# Altium schematic sheet PAGE3-BLE, LORA, RTC.SchDoc("PAGE3-BLE, LORA, RTC")
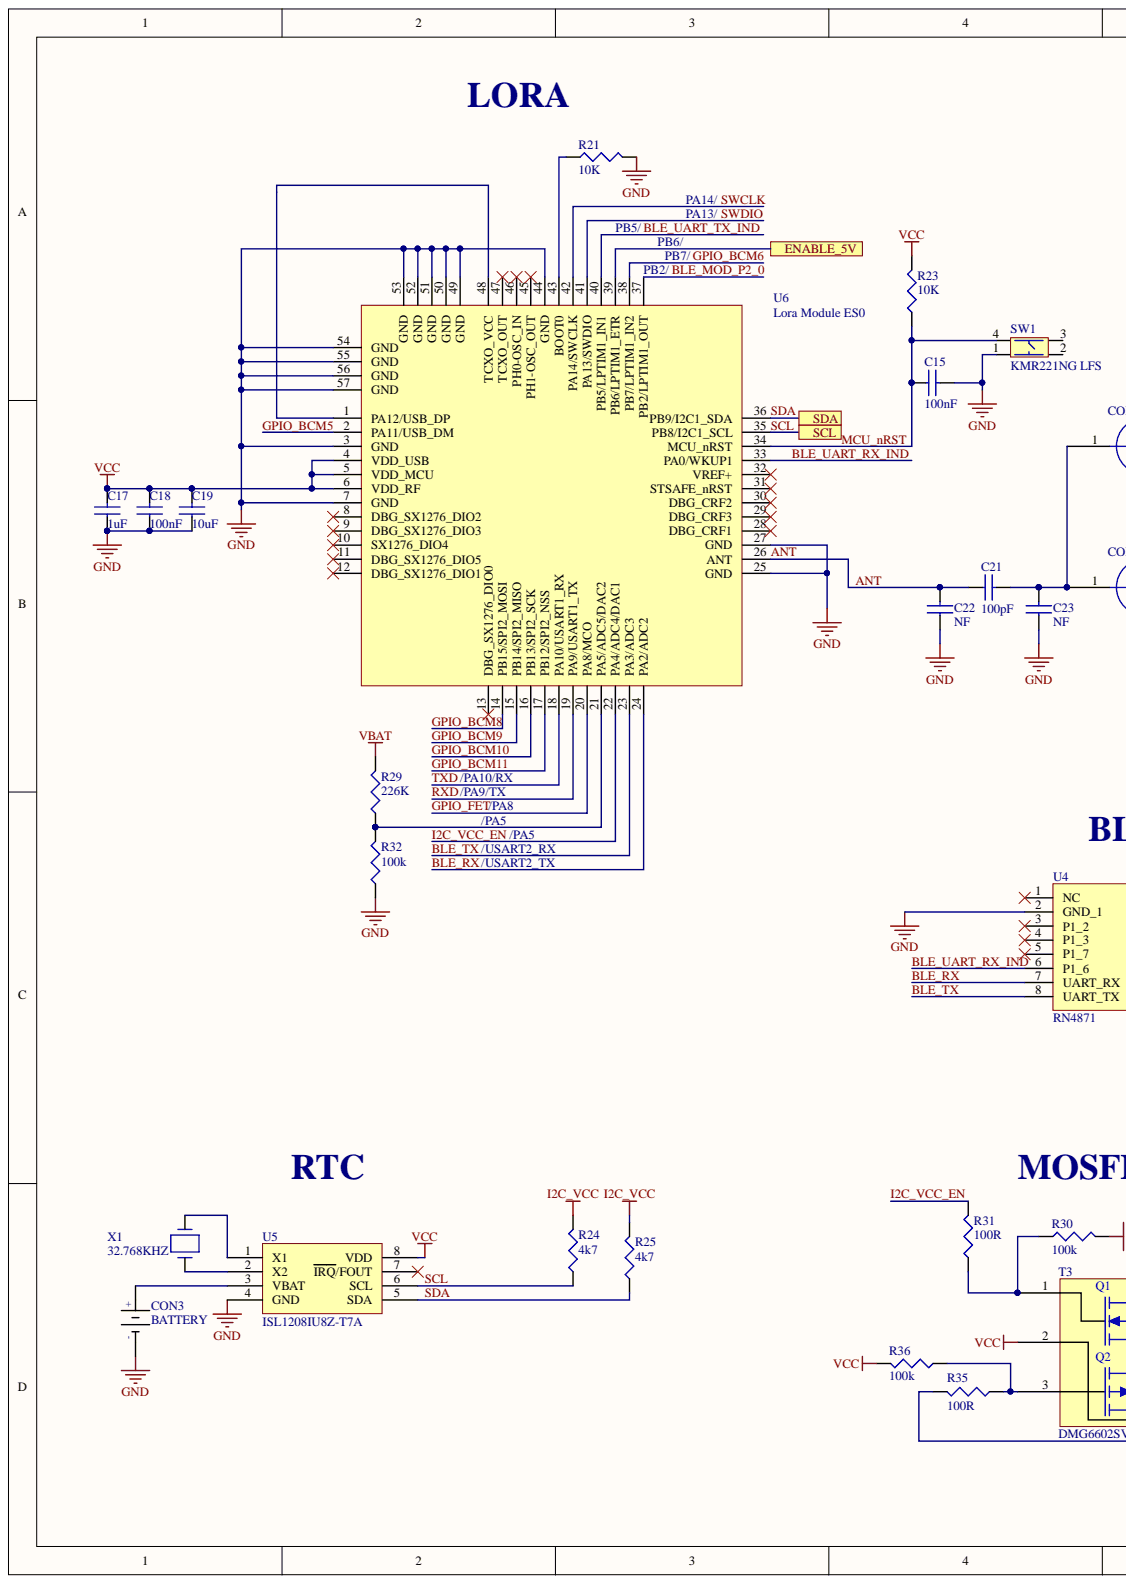
[diagram p0: PAGE3-BLE, LORA, RTC.SchDoc, left]
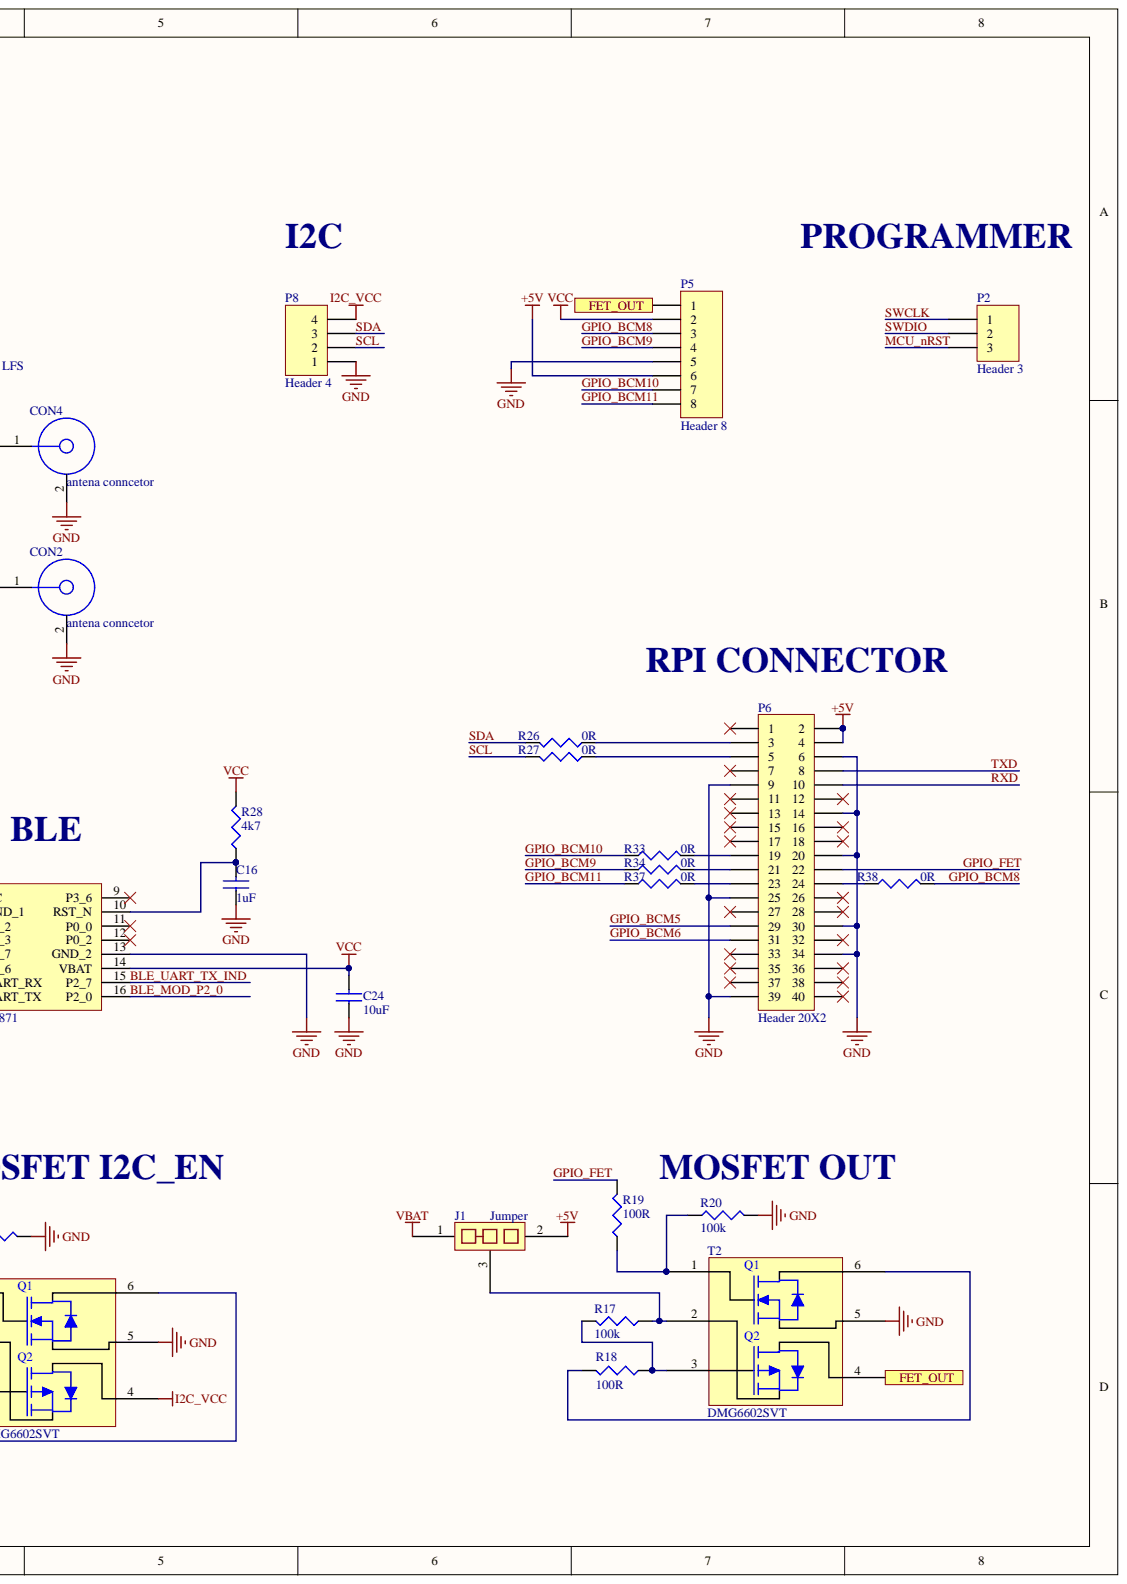
[diagram p1: PAGE3-BLE, LORA, RTC.SchDoc, right]

## Parts
C15  100nF  [CAP0603]
C16  1uF  [CAP0603]
C17  1uF  [CAP0603]
C18  100nF  [CAP0603]
C19  10uF  [CAP0603]
C21  100pF  [CAP0402]
C22  NF  [CAP0402]
C23  NF  [CAP0402]
C24  10uF  [CAP0603]
CON2  antena conncetor  [ufl connector smd]
CON3  BATTERY  [CR-2032/VCN]
CON4  antena conncetor  [ufl connector smd]
J1  Jumper  [JUMPER_NC]
P2  Header 3  [HDR1X3]
P5  Header 8  [HDR1X8]  (no BOM)
P6  Header 20X2  [HDR2X20]  (no BOM)
P8  Header 4  [HDR1X4]
R17  100k  [RES0603]
R18  100R  [RES0603]
R19  100R  [RES0603]
R20  100k  [RES0603]
R21  10K  [RES0603]
R23  10K  [RES0603]
R24  4k7  [RES0603]
R25  4k7  [RES0603]
R26  0R  [RES0603]
R27  0R  [RES0603]
R28  4k7  [RES0603]
R29  226K  [RES0603]
R30  100k  [RES0603]
R31  100R  [RES0603]
R32  100k  [RES0603]
R33  0R  [RES0603]
R34  0R  [RES0603]
R35  100R  [RES0603]
R36  100k  [RES0603]
R37  0R  [RES0603]
R38  0R  [RES0603]
SW1  KMR221NG LFS  [Tactile button]
T2  DMG6602SVT  [TSOT26]
T3  DMG6602SVT  [TSOT26]
U4  RN4871  [RN4871]
U5  ISL1208IU8Z-T7A  [TSOP65P500X110-8N]
U6  Lora Module ES0  [Lora Module ES0]
X1  32.768KHZ  [LFXTAL009678]

## Ports
ENABLE_5V, FET_OUT, SCL, SDA

## Nets
+5V: J1.2 P5.6 P6.2 P6.4
GND: C15.2 C16.1 C17.1 C18.1 C19.1 C22.1 C23.1 C24.1 CON2.2 CON3.NEG CON4.2 P5.5 P6.6 P6.9 P6.14 P6.20 P6.25 P6.30 P6.34 P6.39 P8.1 R20.2 R21.2 R30.2 R32.1 SW1.1 T2.5 T3.5 U4.2(GND_1) U4.13(GND_2) U5.4(GND) U6.3(GND) U6.7(GND) U6.25(GND) U6.27(GND) U6.44(GND) U6.49(GND) U6.50(GND) U6.51(GND) U6.52(GND) U6.53(GND) U6.54(GND) U6.55(GND) U6.56(GND) U6.57(GND)
I2C_VCC: P8.4 R24.2 R25.2 T3.4
VBAT: J1.1 R29.2
VCC: C17.2 C18.2 C19.2 C24.2 P5.2 R23.2 R28.2 R36.2 T3.2 U4.14(VBAT) U5.8(VDD) U6.4(VDD_USB) U6.5(VDD_MCU) U6.6(VDD_RF)
ANT: C21.1 C22.2 U6.26(ANT)
BLE_MOD_P2_0: U4.16(P2_0) U6.37(PB2/LPTIM1_OUT)
BLE_RX: U4.7(UART_RX) U6.24(PA2/ADC2)
BLE_TX: U4.8(UART_TX) U6.23(PA3/ADC3)
BLE_UART_RX_IND: U4.6(P1_6) U6.33(PA0/WKUP1)
BLE_UART_TX_IND: U4.15(P2_7) U6.40(PB5/LPTIM1_IN1)
ENABLE_5V: U6.39(PB6/LPTIM1_ETR) ; port ENABLE_5V
FET_OUT: P5.1 T2.4 ; port FET_OUT
GPIO_BCM5: P6.29 U6.2(PA11/USB_DM)
GPIO_BCM6: P6.31 U6.38(PB7/LPTIM1_IN2)
GPIO_BCM8: P5.3 R38.2 U6.14(PB15/SPI2_MOSI)
GPIO_BCM9: P5.4 R34.1 U6.15(PB14/SPI2_MISO)
GPIO_BCM10: P5.7 R33.1 U6.16(PB13/SPI2_SCK)
GPIO_BCM11: P5.8 R37.1 U6.17(PB12/SPI2_NSS)
GPIO_FET: P6.22 R19.2 U6.20(PA8/MCO)
I2C_VCC_EN: R31.2 U6.22(PA4/ADC4/DAC1)
MCU_nRST: C15.1 P2.3 R23.1 SW1.4 U6.34(MCU_nRST)
RXD: P6.10 U6.19(PA9/USART1_TX)
SCL: P8.2 R24.1 R27.2 U5.6(SCL) U6.35(PB8/I2C1_SCL) ; port SCL
SDA: P8.3 R25.1 R26.2 U5.5(SDA) U6.36(PB9/I2C1_SDA) ; port SDA
SWCLK: P2.1 U6.42(PA14/SWCLK)
SWDIO: P2.2 U6.41(PA13/SWDIO)
TXD: P6.8 U6.18(PA10/USART1_RX)
NetC16_2: C16.2 R28.1 U4.10(RST_N)
NetC21_2: C21.2 C23.2 CON2.1 CON4.1
NetCON3_POS: CON3.POS U5.3(VBAT)
NetJ1_3: J1.3 R17.1 T2.2
NetP6_3: P6.3 R26.1
NetP6_5: P6.5 R27.1
NetP6_19: P6.19 R33.2
NetP6_21: P6.21 R34.2
NetP6_23: P6.23 R37.2
NetP6_24: P6.24 R38.1
NetR17_2: R17.2 R18.1 T2.3
NetR18_2: R18.2 T2.6
NetR19_1: R19.1 R20.1 T2.1
NetR21_1: R21.1 U6.43(BOOT0)
NetR29_1: R29.1 R32.2 U6.21(PA5/ADC5/DAC2)
NetR30_1: R30.1 R31.1 T3.1
NetR35_1: R35.1 R36.1 T3.3
NetR35_2: R35.2 T3.6
NetU5_1: U5.1(X1) X1.2
NetU5_2: U5.2(X2) X1.1
NetU6_1: U6.1(PA12/USB_DP) U6.48(TCXO_VCC)

Not connected: P6 1 7 11 12 13 15 16 17 18 26 27 28 32 33 35 36 37 38 40; SW1 2 3; U4 1(NC) 3(P1_2) 4(P1_3) 5(P1_7) 9(P3_6) 11(P0_0) 12(P0_2); U5 7(IRQ/FOUT); U6 8(DBG_SX1276_DIO2) 9(DBG_SX1276_DIO3) 10(SX1276_DIO4) 11(DBG_SX1276_DIO5) 12(DBG_SX1276_DIO1) 13(DBG_SX1276_DIO0) 28(DBG_CRF1) 29(DBG_CRF3) 30(DBG_CRF2) 31(STSAFE_nRST) 32(VREF+) 45(PH1-OSC_OUT) 46(PH0-OSC_IN) 47(TCXO_OUT)

## Library data of the parts
C15 C18 = 100nF: MURATA - GRM188R71C104KA01J - CAP, MLCC, X7R, 0.1UF, 16V, 0603; Voltage Rating: 16; SVHC: No SVHC (20-Jun-2016; Product Range: GRM Series; Dielectric Characteristic: X7; Ceramic Capacitor Case: 0603 [1608 Metric]; Capacitance Tolerance: ± 10%; Capacitance: 0.1; Supplier Part Number 1: 2688519; Supplier 1: Farnell; Stock 1: 18297; RoHS: YES; Pricing 1: 10=0.0145, 100=0.0126, 500=0.0081, 2500=0.0059, 5000=0.0052, 10000=0.0046, 50000=0.0036, 100000=0.0035 (GBP); Packaging: Cut Tape; Operating Temperature Min: -55; Operating Temperature Max: 125; MSL: MSL 1 - Unlimited; Manufacturer Part Number: GRM188R71C104KA01J; Manufacturer: MURATA; Library Name: Capacitors.IntLib; ComponentLink1URL: http://www.farnell.com/datasheets/2189124.pdf; ComponentLink1Description: http://www.farnell.com/datasheets/2189124.pdf; Component Kind: Standard; Automotive Qualification Standard: -
C16 C17 = 1uF: MURATA - GRM188R61H105KAALD - CAP, MLCC, X5R, 1UF, 50V, 0603; Voltage Rating: 50; SVHC: No SVHC (17-Dec-2015); Product Range: GRM Series; Dielectric Characteristic: X5; Ceramic Capacitor Case: 0603 [1608 Metric]; Capacitor Terminals: SMD<!--FANDB--><div class="features-and-benefits-container"><div class="features-and-benefits-description"><span class="features-benefits-value">The Murata GRM series capacitors are Multilayer Ceramic Chip Capacitors (MLCC) used for general purpose applications. These MLCC capacitors are classified into temperature compensating and high dielectric constant types depending on the change in electrostatic capacitance due to change in temperature. The temperature compensating capacitors feature a small rate of change in electrostatic capacitance as the temperature changes and are used for applications such as filters and high frequency circuit matching. The high dielectric constant capacitors use materials with a high dielectric constant and feature a large electrostatic capacitance. Typical applications are power supply decoupling and smoothing circuits.</span></div><div class="features-and-benefits-list"><ul class="features-and-benefits-list-list"><li class="features-and-benefits-list-item">Large capacity and small size in a multilayer structure</li><li class="features-and-benefits-list-item">Capacitance value ranging from 0.1pF to 220?F</li><li class="features-and-benefits-list-item">Tin plated external electrodes, excellent solderability</li><li class="features-and-benefits-list-item">Provides good high frequency characteristics</li><li class="features-and-benefits-list-item">Excellent pulse response</li><li class="features-and-benefits-list-item">High reliability</li><li class="features-and-benefits-list-item">Capacitor size varies from 0.4×0.2mm to 5.7×5.0mm</li><li class="features-and-benefits-list-item">Rated voltage of 2.5VDC to 3.15; Capacitance Tolerance ±: ± 10%; Capacitance: 1; Supplier Part Number 1: 1845736; Supplier 1: Farnell; Stock 1: 62682; RoHS: YES; Pricing 1: 10=0.093, 100=0.062, 500=0.0507, 1000=0.0465, 2000=0.0429, 4000=0.0399, 20000=0.0372, 40000=0.0365 (GBP); Packaging: Cut Tape; Operating Temperature Min: -55; Operating Temperature Max: 85; MSL: -; Manufacturer Part Number: GRM188R61H105KAALD; Manufacturer: MURATA; Library Name: Capacitors.IntLib; ComponentLink1URL: http://www.farnell.com/datasheets/2048611.pdf; ComponentLink1Description: http://www.farnell.com/datasheets/2048611.pdf; Component Kind: Standard; Capacitance Tolerance: ± 10%; Automotive Qualification Standard: -
C19 C24 = 10uF: 10uf/0603/20%; Manufacturer Part Number: C0603C106M8PACTU; Manufacturer: KEMET; Supplier Part Number 1: 2820985; Supplier 1: Farnell; Stock 1: 0; Pricing 1: 10=0.555, 100=0.315, 500=0.238, 1000=0.2, 2000=0.174, 4000=0.143, 20000=0.123 (GBP); Component Kind: Standard; Library Name: pira-smart-schlib.SchLib
C21 = 100pF/0402: Cap Ceramic 100pF 50V X7R 10% SMD 0402 125C T/R; Manufacturer Part Number: C0402C101K5RACTU; Manufacturer: KEMET; Width: 0.5mm; Voltage Rating (DC): 50V; Voltage Rating: 50V; Tolerance: 10%; Thickness: 0.02inch; Termination: SMD/SMT; Supplier Part Number 1: 2522375; Supplier 1: Farnell; Stock 1: 35279; REACH SVHC: No SVHC; Pricing 1: 10=0.0252, 100=0.0202, 500=0.0126, 2500=0.0084, 5000=0.0072 (EUR); Packaging: Tape and Reel; Package Quantity: 10000; Mount: Surface Mount; Min Operating Temperature: -55°C; Max Operating Temperature: 125°C; Library Name: Capacitors.IntLib; Length: 1mm; Dielectric Material: Ceramic; Dielectric: X7R; Depth: 0.5mm; ComponentLink3URL: http://www.mouser.com/ds/2/212/KEM_C1002_X7R_SMD-1102033.pdf; ComponentLink3Description: http://www.mouser.com/ds/2/212/KEM_C1002_X7R_SMD-1102033.pdf; ComponentLink2URL: http://www.farnell.com/datasheets/2112152.pdf; ComponentLink2Description: http://www.farnell.com/datasheets/2112152.pdf; ComponentLink1URL: http://components.arrow.com/part/dataview/48781706S8928725N7429; ComponentLink1Description: http://components.arrow.com/part/dataview/48781706S8928725N7429; Component Kind: Standard; Case Code (Metric): 1005; Case Code (Imperial): 0402; Case/Package: 0402; Capacitance: 100pF
C22 C23 = CAP0402: Cap 0402 footprint only; Component Kind: Standard; Library Name: pira-smart-schlib.SchLib
CON2 CON4 = Antena copnnector: CONN UMC JACK STR 50 OHM SMD; Manufacturer Part Number: U.FL-R-SMT-1(10); Manufacturer: Hirose; Shield Termination: Solder; Other Names: H11891TR  Q5400621  U.FL-R-SMT-1 10  U.FL-R-SMT-1(10)-ND  UFLRSMT110; Online Catalog: UMCC (Ultra Miniature Coax Connectors), U.FL Series; Impedance: 50 Ohm; Frequency - Max: 6GHz; Featured Product: U.FL Series Connectors; Dielectric Material: Liquid Crystal Polymer (LCP); Connector Type: Receptacle, Male Pin; Connector Style: U.FL, Ultra Miniature Coaxial; Center Contact Plating: Gold; Center Contact Material: Brass; Body Material: Phosphor Bronze; Body Finish: Silver; Voltage Rating: -; Supplier Part Number 1: 1688077; Supplier 1: Farnell; Stock 1: 0; Standard Package: 2,500; Series: U.FL; Packaging: Tape & Reel (TR); Operating Temperature: -40°C ~ 90°C; Mounting Type: Surface Mount; Ingress Protection: -; Housing Color: Beige; Features: -; Fastening Type: Snap-On; Family: Coaxial Connectors (RF); Contact Termination: Solder; ComponentLink3URL: http://www.farnell.com/datasheets/1947917.pdf; ComponentLink3Description: http://www.farnell.com/datasheets/1947917.pdf; ComponentLink2URL: http://uk.rs-online.com/webdocs/1363/0900766b81363563.pdf; ComponentLink2Description: http://uk.rs-online.com/webdocs/1363/0900766b81363563.pdf; ComponentLink1URL: http://www.farnell.com/datasheets/91524.pdf; ComponentLink1Description: http://www.farnell.com/datasheets/91524.pdf; Component Kind: Standard; Category: Connectors, Interconnects; Cable Group: -; Library Name: pira-smart-schlib.SchLib
CON3 = CR-2032/VCN: BATT LITH COIN 3V CELL PC PINS; Manufacturer: Panasonic BSG; Rechargeable/Non-Rechargeable: Non-Rechargeable; Chemistry: Lithium; Capacity: 225 mAh; Brand: Panasonic Battery; Battery Size: 2032; Type: Lithium Primary Coil Batteries; Termination Style: Through Hole; Supplier Part Number 1: 1892670; Supplier 1: Farnell; Stock 1: 1004; Series: CR; RoHS: Not Applicable; Product Category: Coin Cell Battery; Pricing 1: 1=0.91, 50=0.892, 100=0.874, 500=0.855, 1000=0.837 (GBP); Part # Aliases: CR-2032/VCN; Packaging: Bulk; Output Voltage: 3 V; Orientation: Vertical; Operating Temperature Range: - 30 C to + 60 C; Manufacturer Part Number: CR-2032/VCN; Height: 3.2 mm; Factory Pack Quantity: 480; Diameter: 20 mm; ComponentLink3URL: https://na.industrial.panasonic.com/sites/default/pidsa/files/panasonic-lithium-cr2032-datasheet.pdf; ComponentLink3Description: https://na.industrial.panasonic.com/sites/default/pidsa/files/panasonic-lithium-cr2032-datasheet.pdf; ComponentLink2URL: http://uk.rs-online.com/webdocs/108e/0900766b8108ebf4.pdf; ComponentLink2Description: http://uk.rs-online.com/webdocs/108e/0900766b8108ebf4.pdf; ComponentLink1URL: http://www.mouser.com/ds/2/315/AAA4000CE51-586417.pdf; ComponentLink1Description: http://www.mouser.com/ds/2/315/AAA4000CE51-586417.pdf; Component Kind: Standard; Library Name: pira-smart-schlib.SchLib
P2 = Header 3: Header, 3-Pin; Signal Integrity: Connector; Library Name: Miscellaneous Connectors.IntLib; LatestRevisionNote: Re-released for DXP Platform.; LatestRevisionDate: 17-Jul-2002; Component Kind: Standard; Publisher: Altium Limited
P5 = Header 8: Header, 8-Pin; Signal Integrity: Connector; Library Name: Miscellaneous Connectors.IntLib; LatestRevisionNote: Re-released for DXP Platform.; LatestRevisionDate: 17-Jul-2002; Publisher: Altium Limited
P6 = Header 20X2: Header, 20-Pin, Dual row; Signal Integrity: Connector; Library Name: Miscellaneous Connectors.IntLib; LatestRevisionNote: Re-released for DXP Platform.; LatestRevisionDate: 17-Jul-2002; Publisher: Altium Limited
P8 = Header 4: Header, 4-Pin; Signal Integrity: Connector; Library Name: Miscellaneous Connectors.IntLib; LatestRevisionNote: Re-released for DXP Platform.; LatestRevisionDate: 17-Jul-2002; Component Kind: Standard; Publisher: Altium Limited
R17 R20 R30 R32 R36 = 100k: MULTICOMP - MCMR06X104 JTL - RES, CERAMIC, 100K, 5%, 0.1W, 0603; Voltage Rating: 75; Temperature Coefficient: ± 100; Resistor Mounting: Surface Mount Device<!--FANDB--><div class="features-and-benefits-container"><div class="features-and-benefits-description"><span class="features-benefits-value">The Multicomp MCMR series resistors are automotive grade, anti sulphured thick film chip resistor. The resistance range includes E24 and E96 decade values from 1ohm to 10Mohm. These resistors are constructed on top of a high grade ceramic body.</span></div><div class="features-and-benefits-list"><ul class="features-and-benefits-list-list"><li class="features-and-benefits-list-item">EIA 0402, 0603, 0805, 1206 chip sizes available</li><li class="features-and-benefits-list-item">High reliability and stability</li><li class="features-and-benefits-list-item">Automotive grade AEC-Q200 compliant</li><li class="features-and-benefits-list-item">Complaint with (IEC 60068-1) 55/155/56 climatic category</li><li class="features-and-benefits-list-item">Maximum operation voltage of 50V, 75V, 150V and 200V</li><li class="features-and-benefits-list-item">Power rating of 62.5mW, 100mW, 125mW and 250mW</li><li class="features-and-benefits-list-item">Available in ±1% and ±5% tolerance</li><li class="features-and-benefits-list-item">Temperature coefficient (TCR) of +200ppm/°C, +100ppm/°C and -200ppm/°C to +400ppm/°C</li><li class="features-and-benefits-list-item">Operating temperature range -55°C to 155; Resistor Case Style: 0603 [1608 Metric]; Resistance: 100; Product Range: MCMR Series; Supplier Part Number 1: 2073357; Supplier 1: Farnell; Stock 1: 187; RoHS: Y-EX; Resistor Element Material: Ceramic; Resistance Tolerance: ± 5%; Pricing 1: 10=0.0109, 100=0.0085, 500=0.006, 1000=0.0048, 2500=0.004, 5000=0.003, 25000=0.002, 50000=0.0016 (GBP); Power Rating: 100; Packaging: Cut Tape; Manufacturer Part Number: MCMR06X104 JTL; Manufacturer: MULTICOMP; Library Name: Resistors.IntLib; ComponentLink1URL: http://www.farnell.com/datasheets/1716707.pdf; ComponentLink1Description: http://www.farnell.com/datasheets/1716707.pdf; Component Kind: Standard; Automotive Qualification Standard: -
R18 R19 R31 R35 = 100R: MULTICOMP - MC0063W06031100R - RES, THICK FILM, 100R, 1%, 0.063W, 0603; Voltage Rating V DC: 50V<!--FANDB--><div class="features-and-benefits-container"><div class="features-and-benefits-description"><span class="features-benefits-value">The Multicomp MC series current sense resistors are thick film chip resistors. The resistance range includes E96 and E24 decade values from 1ohm to 10Mohm.</span></div><div class="features-and-benefits-list"><ul class="features-and-benefits-list-list"><li class="features-and-benefits-list-item">EIA 0402, 0603, 0805, 1206 chip sizes available</li><li class="features-and-benefits-list-item">Small size and low weight</li><li class="features-and-benefits-list-item">Suitable for both wave and reflow soldering</li><li class="features-and-benefits-list-item">Reduction of assembly costs</li><li class="features-and-benefits-list-item">Maximum working voltage of 50V(0402, 0603), 150V(0805), 200V(1206)</li><li class="features-and-benefits-list-item">Available in ±1%, ±2% and ±5% tolerance</li><li class="features-and-benefits-list-item">Power rating of 62.5mW, 100mW and 125mW</li><li class="features-and-benefits-list-item">±400ppm/°C(1ohm to 10ohm), ±200ppm/°C(11ohm to 100ohm), ±100; Voltage Rating: 50; Temperature Coefficient: ± 200; Temperature Coeff. -: 200; SVHC: No SVHC (12-Jan-2017); Resistor Mounting: Surface Mount Device; Resistor Case Style: 0603 [1608 Metric]; Resistance: 100; Reel Quantity: 5000; Product Range: MC Series; Power Rating: 63; No. of Pins: 2; External Width: 0.8; External Length / Height: 1.6; External Depth: 0.45; Tolerance -: 1%; Tolerance +: 1%; Temperature Coeff. +: 200; Tape Width: 8; Supplier Part Number 1: 2447227; Supplier 1: Farnell; RoHS: Y-EX; Resistor Element Material: Thick Film; Resistance Tolerance: ± 1%; Packaging: Cut Tape; Operating Temperature Range: -55°C to +155; Operating Temperature Min: -55; Operating Temperature Max: 155; Manufacturer Part Number: MC0063W06031100R; Manufacturer: MULTICOMP; Library Name: Resistors.IntLib; ComponentLink3URL: http://www.farnell.com/datasheets/2289252.pdf; ComponentLink3Description: http://www.farnell.com/datasheets/2289252.pdf; ComponentLink2URL: http://www.farnell.com/cad/1561149.zip; ComponentLink2Description: http://www.farnell.com/cad/1561149.zip; ComponentLink1URL: http://www.farnell.com/datasheets/1788326.pdf; ComponentLink1Description: http://www.farnell.com/datasheets/1788326.pdf; Component Kind: Standard; Automotive Qualification Standard: -
R21 R23 = 10K: 10K resistor; Component Kind: Standard; Library Name: pira-smart-schlib.SchLib
R24 R25 R28 = 4k7: MULTICOMP - MCMR06X4701FTL - RES, CERAMIC, 4K7, 1%, 0.1W, 0603; Voltage Rating: 75; Temperature Coefficient: ± 100; SVHC: No SVHC (12-Jan-2017)<!--FANDB--><div class="features-and-benefits-container"><div class="features-and-benefits-description"><span class="features-benefits-value">The Multicomp MCMR series resistors are automotive grade, anti sulphured thick film chip resistor. The resistance range includes E24 and E96 decade values from 1ohm to 10Mohm. These resistors are constructed on top of a high grade ceramic body.</span></div><div class="features-and-benefits-list"><ul class="features-and-benefits-list-list"><li class="features-and-benefits-list-item">EIA 0402, 0603, 0805, 1206 chip sizes available</li><li class="features-and-benefits-list-item">High reliability and stability</li><li class="features-and-benefits-list-item">Automotive grade AEC-Q200 compliant</li><li class="features-and-benefits-list-item">Complaint with (IEC 60068-1) 55/155/56 climatic category</li><li class="features-and-benefits-list-item">Maximum operation voltage of 50V, 75V, 150V and 200V</li><li class="features-and-benefits-list-item">Power rating of 62.5mW, 100mW, 125mW and 250mW</li><li class="features-and-benefits-list-item">Available in ±1% and ±5% tolerance</li><li class="features-and-benefits-list-item">Temperature coefficient (TCR) of +200ppm/°C, +100ppm/°C and -200ppm/°C to +400ppm/°C</li><li class="features-and-benefits-list-item">Operating temperature range -55°C to 155; Resistor Case Style: 0603 [1608 Metric]; Resistance: 4.7; Product Range: MCMR Series; Power Rating: 100; Supplier Part Number 1: 2073509; Supplier 1: Farnell; Stock 1: 218618; RoHS: Y-EX; Resistor Element Material: Ceramic; Resistance Tolerance: ± 1%; Pricing 1: 10=0.0085, 100=0.0051, 500=0.0036, 1000=0.0028, 2500=0.0023 (GBP); Packaging: Cut Tape; Manufacturer Part Number: MCMR06X4701FTL; Manufacturer: MULTICOMP; Library Name: Resistors.IntLib; ComponentLink1URL: http://www.farnell.com/datasheets/1716707.pdf; ComponentLink1Description: http://www.farnell.com/datasheets/1716707.pdf; Component Kind: Standard; Automotive Qualification Standard: -
R26 R27 R33 R34 R37 R38 = 0R: BOURNS - CR0603-J/-000ELF - RES, THICK FILM, 0R, 5%, 0.1W, 0603; Voltage Rating: 50; SVHC: No SVHC (17-Dec-2015); Resistor Mounting: Surface Mount Device<!--FANDB--><div class="features-and-benefits-container"><div class="features-and-benefits-description"><span class="features-benefits-value">The Bourns CR series are standard thick film chip resistors. These resistors provide flexibility of performance, space saving and low cost. The Resistance range includes E96 and E24 decade values from 1ohm to 10Mohm.</span></div><div class="features-and-benefits-list"><ul class="features-and-benefits-list-list"><li class="features-and-benefits-list-item">0201, 0402, 0603, 0805, 1206, 1210, 2010, 2512 standard case sizes available</li><li class="features-and-benefits-list-item">±1% and ±5% tolerance</li><li class="features-and-benefits-list-item">±100ppm/°C, ±200ppm/°C and ±250ppm/°C TCR values available</li><li class="features-and-benefits-list-item">Power rating selectable for 50mW, 63mW, 0.1W, 0.125W, 0.25W, 0.5W and 1W based on ordering</li><li class="features-and-benefits-list-item">Jumpers are available for all size</li><li class="features-and-benefits-list-item">3 layer termination process with nickel barrier prevents leaching & provides excellent solderability</li><li class="features-and-benefits-list-item">Suitable for all type of soldering processes</li><li class="features-and-benefits-list-item">Tight dimensional tolerances</li><li class="features-and-benefits-list-item">Maximum working voltage depends on case size</li><li class="features-and-benefits-list-item">Operating temperature of -55°C to +125°C(CR0201) and -55°C to +155; Resistor Case Style: 0603 [1608 Metric]; Resistance: 0; Product Range: CR Series; Power Rating: 100; Temperature Coefficient: -; Supplier Part Number 1: 2008343; Supplier 1: Farnell; Stock 1: 58200; RoHS: YES; Resistor Element Material: Thick Film; Resistance Tolerance: ± 5%; Pricing 1: 10=0.0146, 250=0.0097, 1000=0.0073, 5000=0.0049, 12500=0.0042 (GBP); Packaging: Cut Tape; MSL: MSL 1 - Unlimited; Manufacturer Part Number: CR0603-J/-000ELF; Manufacturer: BOURNS; Library Name: Resistors.IntLib; ComponentLink2URL: http://www.farnell.com/datasheets/2178762.pdf; ComponentLink2Description: http://www.farnell.com/datasheets/2178762.pdf; ComponentLink1URL: http://www.bourns.com/engineering/CHPREZTR/cr0603.stp; ComponentLink1Description: http://www.bourns.com/engineering/CHPREZTR/cr0603.stp; Component Kind: Standard; Automotive Qualification Standard: -
R29 = 226K: Thick Film Resistors - SMD 1/10watt 226Kohms 1%; Manufacturer Part Number: CRCW0603226KFKEA; Manufacturer: Vishay; Voltage Rating: 75 V; Unit Weight: 0.000071 oz; Temperature Coefficient: 100 PPM / K; Power Rating: 100 mW; Brand: Vishay / Dale; Width: 0.85 mm; Tolerance: 1 %; Termination Style: SMD/SMT; Supplier Part Number 1: 2138531; Supplier 1: Farnell; Stock 1: 6700; Series: CRCW; RoHS: Details; Resistance: 226 kOhms; Product Category: Thick Film Resistors - SMD; Product: Thick Film Resistors SMD; Pricing 1: 10=0.0188, 100=0.0145, 500=0.0124, 1000=0.008 (GBP); Packaging: Reel; Package / Case: 0603 (1608 metric); Operating Temperature Range: - 55 C to + 155 C; Length: 1.55 mm; Height: 0.45 mm; Factory Pack Quantity: 5000; ComponentLink7URL: http://www.farnell.com/datasheets/2111203.pdf; ComponentLink7Description: http://www.farnell.com/datasheets/2111203.pdf; ComponentLink6URL: http://www.alliedelec.com/m/d/0c934d6939ccefa68dbe0b96d821c8cf.pdf; ComponentLink6Description: http://www.alliedelec.com/m/d/0c934d6939ccefa68dbe0b96d821c8cf.pdf; ComponentLink5URL: http://uk.rs-online.com/webdocs/0d98/0900766b80d98afc.pdf; ComponentLink5Description: http://uk.rs-online.com/webdocs/0d98/0900766b80d98afc.pdf; ComponentLink4URL: https://www.alliedelec.com/m/d/0c934d6939ccefa68dbe0b96d821c8cf.pdf; ComponentLink4Description: https://www.alliedelec.com/m/d/0c934d6939ccefa68dbe0b96d821c8cf.pdf; ComponentLink3URL: http://www.farnell.com/datasheets/2045674.pdf; ComponentLink3Description: http://www.farnell.com/datasheets/2045674.pdf; ComponentLink2URL: http://www.vishay.com/docs/20035/dcrcwe3.pdf; ComponentLink2Description: http://www.vishay.com/docs/20035/dcrcwe3.pdf; ComponentLink1URL: http://www.mouser.com/ds/2/427/dcrcwe3-109170.pdf; ComponentLink1Description: http://www.mouser.com/ds/2/427/dcrcwe3-109170.pdf; Component Kind: Standard; Case Code - mm: 1608; Case Code - in: 0603; Library Name: pira-smart-schlib.SchLib
SW1 = Tactile button: SWITCH TACTILE SPST-NO 0.05A 32V; Manufacturer: ITT C&K; Switch Configuration: N.O.; Pole Throw Configuration: SPST; Operating Force: 2 N; Minimum Insulation Resistance: >=1000 MOhm; Mechanical Life: 200000 Cycles; Illumination Type: N/R; Ground Terminal: No; Dielectric Strength: >=250 Vrms; Contact Rating @ Voltage: 1 A; Body Orientation: Straight; Actuator Style: Round Button; Actuator Length: 0.5 mm; Type: Micro-Miniature; Supplier Standard Pack: 7,000; Supplier Part Number 1: 2845727; Supplier 1: C&K Components; Stock 1: 4985; Seal Location: N/A; Seal Description: N/A; RoHS: Yes; Product Weight: N/A; Pricing 1: 10=0.278, 500=0.264, 1000=0.208, 5000=0.204, 10000=0.2 (GBP); Part No: KMR221NGLFS; Packaging: Tape and Reel; Operating Temperature: -40 to 85 C; Mounting: Surface Mount,Gull Wing; Maximum DC Voltage Rating: 32 V; Maximum AC Voltage Rating: N/A; Manufacturer Part Number: KMR221NGLFS; HTS: 8536501199; Housing Material: N/A; Family: KMR 2; Electrical Life: N/A; ECCN: EAR99; Dimension: 2.8 x 4.6 x 1.9 mm; Contact Plating: Silver; Contact Material: Silver; ComponentLink4URL: http://www1.futureelectronics.com/doc/C%20-%20K%20COMPONENTS/KMR221NGLFS.pdf; ComponentLink4Description: http://www1.futureelectronics.com/doc/C%20-%20K%20COMPONENTS/KMR221NGLFS.pdf; ComponentLink3URL: http://www.ck-components.com/index.php?module=media&action=Display&cmpref=14414&lang=en&width=&height=&format=&alt=; ComponentLink3Description: http://www.ck-components.com/index.php?module=media&action=Display&cmpref=14414&lang=en&width=&height=&format=&alt=; ComponentLink2URL: http://www.ck-components.com/14414/kmr2_9aug12.pdf/; ComponentLink2Description: http://www.ck-components.com/14414/kmr2_9aug12.pdf/; ComponentLink1URL: https://www.ckswitches.com/media/1479/kmr2.pdf; ComponentLink1Description: https://www.ckswitches.com/media/1479/kmr2.pdf; Component Kind: Standard; Base Material: N/A; Actuator Color: N/A; Library Name: pira-smart-schlib.SchLib
T2 T3 = DMG6602SVT: DIODES INC. - DMG6602SVT - MOSFET, NP CH, , 30V, 3.4, ATSOT23; Transistor Polarity: N and P Channel; Transistor Case Style: TSOT-26; Threshold Voltage Vgs: 1; SVHC: No SVHC (17-Dec-2015); Rds(on) Test Voltage Vgs: 10; Power Dissipation Pd: 1.12; On Resistance Rds(on), P Channel: 0.073; On Resistance Rds(on), N Channel: 0.038; No. of Pins: 6; Module Configuration: Dual; Drain Source Voltage Vds, P Channel: -30; Drain Source Voltage Vds, N Channel: 30; Continuous Drain Current Id, P Channel: -2.8; Continuous Drain Current Id, N Channel: 3.4; Supplier Part Number 1: 2061522; Supplier 1: Farnell; Stock 1: 4881; RoHS: YES; Product Range: -; Pricing 1: 1=0.363, 25=0.339, 100=0.169, 250=0.166, 500=0.108, 1000=0.0809, 2000=0.0793, 6000=0.0777 (GBP); Operating Temperature Range: -55°C to +150°C<!--FANDB--><div class="features-and-benefits-container"><div class="features-and-benefits-description"><span class="features-benefits-value">The DMG6602SVT from Diode Inc is a surface mount complementary pair enhancement mode MOSFET in TSOT-26 package. This MOSFET features low input capacitance, fast switching speed and low input/output leakage, designed to minimize the onstate resistance and maintain superior switching performance, making it ideal for high efficiency power management applications and backlighting.</span></div><div class="features-and-benefits-list"><ul class="features-and-benefits-list-list"><li class="features-and-benefits-list-item">Automotive grade AEC-Q101 qualified</li><li class="features-and-benefits-list-item">UL recognized</li><li class="features-and-benefits-list-item">Drain to source voltage (Vds) of 30V</li><li class="features-and-benefits-list-item">Gate to source voltage (Vgs) of ±20V</li><li class="features-and-benefits-list-item">Continuous drain current of 3.4A</li><li class="features-and-benefits-list-item">Power dissipation (Pd) of 1.27W</li><li class="features-and-benefits-list-item">Operating temperature range -55°C to 150°C</li><li class="features-and-benefits-list-item">Low on state resistance of 38mohm at Vgs of 10; Operating Temperature Min: -55; Operating Temperature Max: 150; On Resistance Rds(on): 0.038; MSL: -; Manufacturer Part Number: DMG6602SVT; Manufacturer: DIODES INC.; Library Name: PiRA-RTC-HAT-PCB.IntLib; Drain Source Voltage Vds: 30; Continuous Drain Current Id: 3.4; ComponentLink1URL: http://www.farnell.com/datasheets/1915929.pdf; ComponentLink1Description: http://www.farnell.com/datasheets/1915929.pdf; Component Kind: Standard; Automotive Qualification Standard: -
U4 = RN4871-V/RM118: MICROCHIP         RN4871-V/RM118             BLE MOD W/ANTENNA, 2.442MHZ, 0.1DBI                          New; SVHC: No SVHC (17-Dec-2015); Supply Voltage Min: 1.9V; Supply Voltage Max: 3.6V; Receive Sensitivity: -90dBm; Product Range: RN Series; Bluetooth Version: Bluetooth 4.2; Bluetooth Class: Class 2; Supplier Part Number 1: 2664514; Supplier 1: Farnell; Stock 1: 2428; Signal Range Max: -; Pricing 1: 1=5.4, 25=5.08, 100=4.98 (GBP); Operating Temperature Min: -20°C; Operating Temperature Max: 70°C; Manufacturer Part Number: RN4871-V/RM118; Manufacturer: Microchip; Data Rate: -; Component Kind: Standard; Library Name: pira-smart-schlib.SchLib
U5 = ISL1208IU8Z-T7A: IC RTC/CALENDAR I2C LP 8MSOP; Manufacturer: Intersil; Time Format: HH:MM:SS; Non-volatile Memory Size: 2B; Min Supply Voltage: 2.7V; Max Supply Voltage: 5.5V; Logic Function: Clock; Interface: I2C,Serial,2-Wire; Date Format: YY-MM-DD-dd; Supplier Part Number 1: 2543322; Supplier 1: Farnell; Stock 1: 321; REACH SVHC: Unknown; Radiation Hardening: No; Pricing 1: 1=2.63, 10=2.24, 25=2.12, 50=2.01, 100=1.89, 250=1.84, 500=1.65, 1000=1.37 (EUR); Packaging: Tape and Reel; Package Quantity: 250; Number of Pins: 8; Mount: Surface Mount; Min Operating Temperature: -40°C; Memory Size: 2B; Max Operating Temperature: 85°C; Manufacturer Part Number: ISL1208IU8Z-T7A; Lead Free: Lead Free; ComponentLink5URL: https://datasheet.ciiva.com/6668/fn8085-6668118.pdf; ComponentLink5Description: https://datasheet.ciiva.com/6668/fn8085-6668118.pdf; ComponentLink4URL: https://datasheet.ciiva.com/6668/fn8085-6668117.pdf; ComponentLink4Description: https://datasheet.ciiva.com/6668/fn8085-6668117.pdf; ComponentLink3URL: https://datasheet.ciiva.com/6668/fn8085-6668119.pdf; ComponentLink3Description: https://datasheet.ciiva.com/6668/fn8085-6668119.pdf; ComponentLink2URL: https://datasheet.ciiva.com/8145/fn8085-8145071.pdf; ComponentLink2Description: https://datasheet.ciiva.com/8145/fn8085-8145071.pdf; ComponentLink1URL: https://datasheet.ciiva.com/16293/fn8085-16293145.pdf; ComponentLink1Description: https://datasheet.ciiva.com/16293/fn8085-16293145.pdf; Component Kind: Standard; Case/Package: MSOP; Library Name: pira-smart-schlib.SchLib
U6 = Lora Module Murata: Manufacturer Part Number: CMWX1ZZABZ-091; Supplier Part Number 1: 2802546; Supplier 1: Farnell; Stock 1: 175; Pricing 1: 1=11.7, 5=11.06, 10=9.94, 50=9.54, 100=9.14, 250=8.05 (GBP); Manufacturer: Murata; Description: Lora Module Murata; Component Kind: Standard; Library Name: pira-smart-schlib.SchLib
X1 = LFXTAL009678: CRYSTAL, 32.768KHZ, 12.5PF, SMD; Manufacturer: IQD; Frequency: 32.8kHz; Supplier Part Number 1: 2449398; Supplier 1: Farnell; Stock 1: 15609; REACH SVHC: No SVHC; Pricing 1: 5=0.283, 50=0.203, 250=0.169, 500=0.163, 1500=0.157, 3000=0.152, 15000=0.146 (EUR); Number of Pins: 2; Min Operating Temperature: -40°C; Max Operating Temperature: 85°C; Manufacturer Part Number: LFXTAL009678; Load Capacitance: 12.5pF; ComponentLink1URL: https://datasheet.ciiva.com/46419/1852967-46419749.pdf; ComponentLink1Description: https://datasheet.ciiva.com/46419/1852967-46419749.pdf; Component Kind: Standard; Case/Package: 217; Library Name: pira-smart-schlib.SchLib
J1 = Jumper: Library Name: Jumper.IntLib; Description: Jumper; Component Kind: Standard
